# Altium schematic sheet Measuring.SchDoc(Measuring)
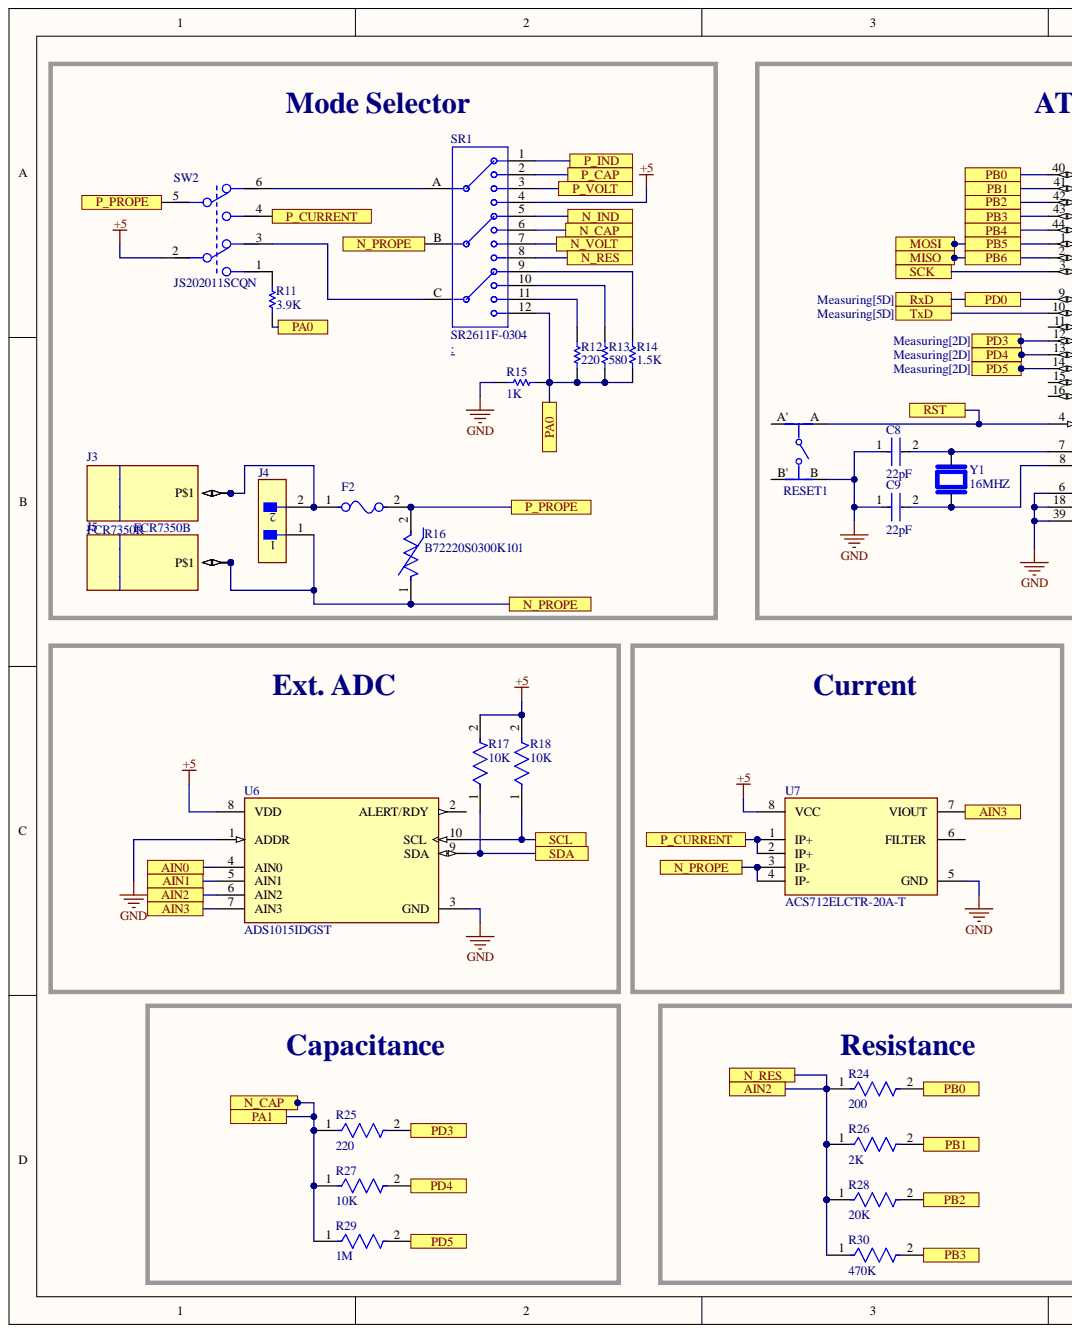
[diagram p0: Measuring.SchDoc, left]
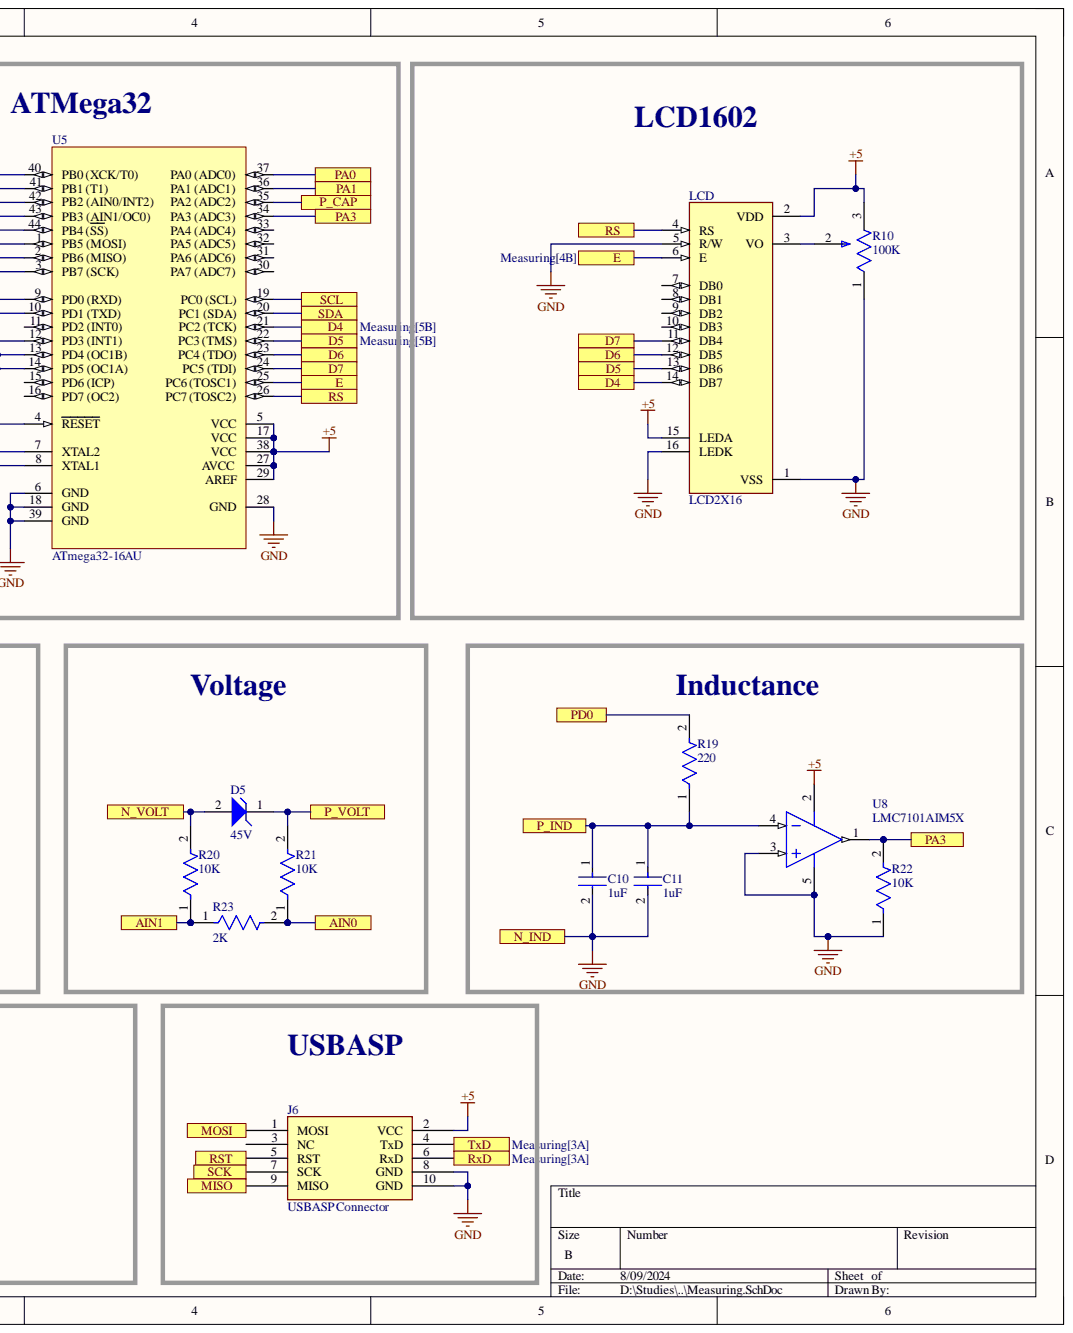
[diagram p1: Measuring.SchDoc, right]

## Parts
C8  22pF  [FP-C0603C-CF-MFG]
C9  22pF  [FP-C0603C-CF-MFG]
C10  1uF  [FP-0603-L_1_6_0_1-W_0_8_0-IPC_A]
C11  1uF  [FP-0603-L_1_6_0_1-W_0_8_0-IPC_A]
D5  45V  [ONSC-SOD-123-2-425-04_V]
F2  0154004.DR  [FP-0154-MFG]  (value not drawn)
J3  FCR7350R  [FCR7350R]
J4  B2B-XH-A(LF)(SN)  [FP-B2B-XH-A_LF_SN-MFG]  (value not drawn)
J5  FCR7350B  MP: FCR7350R  [FCR7350B]
J6  USBASP Connector  [SHDR10W99P254_2X5_2032X889X927P]
LCD  LCD2X16  [LCD_LCD2X16]
R10  100K  [FP-3269W-MFG]
R11  3.9K  [RESC1608X55X25ML10T15]
R12  220  [RESC1608X55X25ML10T15]
R13  580  [RESC1608X55X25ML10T15]
R14  1.5K  [RESC1608X55X25ML10T15]
R15  1K  [RESC1608X55X25ML10T15]
R16  B72220S0300K101  [FP-B72220S0-MFG]
R17  10K  [FP-RC0603-0_55-IPC_C]
R18  10K  [FP-RC0603-0_55-IPC_C]
R19  220  [FP-RC0603-0_55-IPC_C]
R20  10K  [FP-RC0603-0_55-IPC_C]
R21  10K  [FP-RC0603-0_55-IPC_C]
R22  10K  [FP-RC0603-0_55-IPC_C]
R23  2K  [FP-RC0603-0_55-IPC_C]
R24  200  [FP-RC0603-0_55-IPC_C]
R25  220  [FP-RC0603-0_55-IPC_C]
R26  2K  [FP-RC0603-0_55-IPC_C]
R27  10K  [FP-RC0603-0_55-IPC_C]
R28  20K  [FP-RC0603-0_55-IPC_C]
R29  1M  [FP-RC0603-0_55-IPC_C]
R30  470K  [FP-RC0603-0_55-IPC_C]
RESET1  EVQ-Q2B02W  [FP-EVQ-Q2B02W-MFG]  (value not drawn)
SR1  SR2611F-0304  [SW_A30403RNCQ]
SW2  JS202011SCQN  [FP-JS202011SCQN-MFG]
U5  ATmega32-16AU  [44A_N]
U6  ADS1015IDGST  [FP-DGS0010A-MFG]
U7  ACS712ELCTR-20A-T  [FP-LC-8-IPC_C]
U8  LMC7101AIM5X  [DBV0005A_L]
Y1  16MHZ  [XTAL_NX5032GA-16.000000MHZ-LN-CD-1]

## Ports
AIN0, AIN1, AIN2, AIN3, D4, D5, D6, D7, E, MISO, MOSI, N_CAP, N_IND, N_PROPE, N_RES, N_VOLT, P_CAP, P_CURRENT, P_IND, P_PROPE, P_VOLT, PA0, PA1, PA3, PB0, PB1, PB2, PB3, PB4, PB5, PB6, PD0, PD3, PD4, PD5, RS, RST, RxD, SCK, SCL, SDA, TxD

## Nets
+5: J6.2(VCC) LCD.2(VDD) LCD.15(LEDA) R10.3 R17.2 R18.2 SR1.4 SW2.2 U5.5(VCC) U5.17(VCC) U5.27(AVCC) U5.29(AREF) U5.38(VCC) U6.8(VDD) U7.8(VCC) U8.2
AIN0: R21.1 R23.2 U6.4(AIN0) ; port AIN0
AIN1: R20.1 R23.1 U6.5(AIN1) ; port AIN1
AIN2: R24.1 R26.1 R28.1 R30.1 SR1.8 U6.6(AIN2) ; port AIN2, port N_RES
AIN3: U6.7(AIN3) U7.7(VIOUT) ; port AIN3
D4: LCD.14(DB7) U5.21(PC2_(TCK)) ; port D4
D5: LCD.13(DB6) U5.22(PC3_(TMS)) ; port D5
D6: LCD.12(DB5) U5.23(PC4_(TDO)) ; port D6
D7: LCD.11(DB4) U5.24(PC5_(TDI)) ; port D7
E: LCD.6(E) U5.25(PC6_(TOSC1)) ; port E
GND: C8.1 C9.1 C10.2 C11.2 J6.8(GND) J6.10(GND) LCD.1(VSS) LCD.5(R/W) LCD.16(LEDK) R10.1 R15.1 R22.1 RESET1.B SR1.5 U5.6(GND) U5.18(GND) U5.28(GND) U5.39(GND) U6.1(ADDR) U6.3(GND) U7.5(GND) U8.3 U8.5 ; port N_IND
MISO: J6.9(MISO) U5.2(PB6_(MISO)) ; port MISO, port PB6
MOSI: J6.1(MOSI) U5.1(PB5_(MOSI)) ; port MOSI, port PB5
N_CAP: R25.1 R27.1 R29.1 SR1.6 U5.36(PA1_(ADC1)) ; port N_CAP, port PA1
N_PROPE: J4.1 J5.P$1 J5.P$2 J5.P$3 J5.P$4 J5.P$5 R16.1 SR1.B U7.3(IP-) U7.4(IP-) ; port N_PROPE
N_VOLT: D5.2 R20.2 SR1.7 ; port N_VOLT
P_CAP: SR1.2 U5.35(PA2_(ADC2)) ; port P_CAP
P_CURRENT: SW2.4 U7.1(IP+) U7.2(IP+) ; port P_CURRENT
P_IND: C10.1 C11.1 R19.1 SR1.1 U8.4 ; port P_IND
P_PROPE: F2.2 R16.2 SW2.5 ; port P_PROPE
P_VOLT: D5.1 R21.2 SR1.3 ; port P_VOLT
PA0: R11.2 R12.2 R13.2 R14.2 R15.2 SR1.12 U5.37(PA0_(ADC0)) ; port PA0
PA3: R22.2 U5.34(PA3_(ADC3)) U8.1 ; port PA3
PB0: R24.2 U5.40(PB0_(XCK/T0)) ; port PB0
PB1: R26.2 U5.41(PB1_(T1)) ; port PB1
PB2: R28.2 U5.42(PB2_(AIN0/INT2)) ; port PB2
PB3: R30.2 U5.43(PB3_(AIN1/OC0)) ; port PB3
PB4: U5.44(PB4_(SS)) ; port PB4
PD0: J6.6(RxD) R19.2 U5.9(PD0_(RXD)) ; port PD0, port RxD
PD3: R25.2 U5.12(PD3_(INT1)) ; port PD3
PD4: R27.2 U5.13(PD4_(OC1B)) ; port PD4
PD5: R29.2 U5.14(PD5_(OC1A)) ; port PD5
RS: LCD.4(RS) U5.26(PC7_(TOSC2)) ; port RS
RST: J6.5(RST) RESET1.A U5.4(RESET) ; port RST
SCK: J6.7(SCK) U5.3(PB7_(SCK)) ; port SCK
SCL: R18.1 U5.19(PC0_(SCL)) U6.10(SCL) ; port SCL
SDA: R17.1 U5.20(PC1_(SDA)) U6.9(SDA) ; port SDA
TxD: J6.4(TxD) U5.10(PD1_(TXD)) ; port TxD
NetC8_2: C8.2 U5.7(XTAL2) Y1.2
NetC9_2: C9.2 U5.8(XTAL1) Y1.1
NetF2_1: F2.1 J3.P$1 J3.P$2 J3.P$3 J3.P$4 J3.P$5 J4.2
NetLCD_3: LCD.3(VO) R10.2
NetR11_1: R11.1 SW2.1
NetR12_1: R12.1 SR1.11
NetR13_1: R13.1 SR1.10
NetR14_1: R14.1 SR1.9
NetSR1_A: SR1.A SW2.6
NetSR1_C: SR1.C SW2.3

Not connected: J6 3(NC); LCD 7(DB0) 8(DB1) 9(DB2) 10(DB3); RESET1 A' B'; U5 11(PD2_(INT0)) 15(PD6_(ICP)) 16(PD7_(OC2)) 30(PA7_(ADC7)) 31(PA6_(ADC6)) 32(PA5_(ADC5)) 33(PA4_(ADC4)); U6 2(ALERT/RDY); U7 6(FILTER)

## Library data of the parts
C8 C9 = CMP-2006-03119-3: Cap Ceramic 22pF 50V C0G 5% SMD 0603 125°C Paper T/R; Manufacturer: KEMET; Manufacturer Part Number: C0603C220J5GACTU; Automotive Grade: Grade 1; Temperature Coefficient: 30ppm/°C; Tolerance: 0.05; Automotive: Yes; Capacitance: 22 pF; Category: Cap; Component Kind: Standard; ComponentLink2Description: Datasheet; ComponentLink2URL: https://api.kemet.com/component-edge/download/datasheet/C0603C100J5GACAUTO.pdf; Device Class L1: Passive Components; Device Class L2: Capacitors; Device Class L3: Ceramic Capacitors; Digikey Description: Cap Ceramic 22pF 50V C0G 5% SMD 0603 125°C Paper T/R; Height: 0.87mm; Lead Free: Yes; Library Name: Altium Content Vault; Material: Ceramic; Mouser Description: Cap Ceramic 22pF 50V C0G 5% SMD 0603 125°C Paper T/R; Octopart: https://octopart.com/c0603c220j5gactu-kemet-98525; Package: C0603C-CF; RoHS: TRUE; Temperature: -55°C to +125°C; Temperature Characteristic: C0G; Temperature Range High: +125°C; Temperature Range Low: -55°C; Value: 22 pF; Voltage Rating: 50 V
C10 C11 = CMP-2000-05447-3: None; Dielectric: X5R; Tolerance: 10%; Voltage Rating: 50V; Capacitance: 1uF; Case Code (Imperial): 0603; Case Code (Metric): 1608; Case/Package: 0603; Component Kind: Standard; Construction: Flat; Contact Plating: Nickel; Depth: 0.8mm; Halogen Free: Halogen Free; Height: 0.8mm; Length: 1.6mm; Library Name: Altium Content Vault; Max Operating Temperature: 85°C; Min Operating Temperature: -55°C; Mount: Surface Mount; Number of Pins: 2; Package Quantity: 1; Packaging: Tape and Reel; Radiation Hardening: No; RoHS Compliant: Yes; Series: M; Termination: SMD/SMT; Thickness: 0.035inch; Voltage Rating (DC): 50V; Width: 0.031inch
D5 = CMP-1060-00318-1: Zener Voltage Regulator, 500 mW, 2-Pin SOD-123, Pb-Free, Tape and Reel; Manufacturer: On Semiconductor; PartNumber: MMSZ4713T1G; P Max (W): 0.5; VZ Typ (V): 30; Component Kind: Standard; ComponentLink1Description: Manufacturer URL; ComponentLink1URL: http://www.onsemi.com/; ComponentLink2Description: Datasheet; ComponentLink2URL: http://www.onsemi.com/pub_link/Collateral/MMSZ4678T1-D.PDF; ComponentLink3Description: Package Specification; ComponentLink3URL: http://www.onsemi.com/pub_link/Collateral/425-04.PDF; DatasheetVersion: Rev. 9; Library Name: Altium Content Vault; Mounting Technology: Surface Mount; PackageDescription: 2-Pin SOD, Body 1.6 x 2.69 mm; PackageReference: SOD-123-2-425-04; PackageVersion: Rev. G, 10/2009; Packing: Tape and Reel; RoHS: Pb-Free
F2 = CMP-08605-000003-1: FUSE BRD MNT 4A 125VAC/VDC 2SMD; Manufacturer: Littelfuse; Fuse Blow Speed: Fast; Max Fuse Ac Voltage: 125V; Automotive: No; Category: Fuse; Component Kind: Standard; ComponentLink2Description: Datasheet; ComponentLink2URL: https://www.littelfuse.com/~/media/electronics/datasheets/fuses/littelfuse_fuse_154_154t_154l_154tl_datasheet.pdf.pdf; Device Class L1: Circuit Protection; Device Class L2: Fuses; Device Class L3: unset; Digikey Description: FUSE BRD MNT 4A 125VAC/VDC 2SMD; Fuse Current: 4A; Height: 3.81mm; Lead Free: Yes; Library Name: Altium Content Vault; Manufacturer Part Number: 0154004.DR; Max Fuse Dc Voltage: 125V; Mouser Description: Surface Mount Fuses Fuseblock w/ fuse 4A OMNI BLOK 154; Octopart: https://octopart.com/0154004.dr-littelfuse-565861; Package: 0154; RoHS: TRUE; Temperature: -55°C to +125°C; Temperature Range High: +125°C; Temperature Range Low: -55°C
J3 = FCR7350R: Banana Jack Connector Standard Banana Solder Red; MF: Cliff; Check_prices: https://www.snapeda.com/parts/FCR7350R/Cliff+Electronics/view-part/?ref=eda; Component Kind: Standard; MP: FCR7350R; Package: None; SnapEDA_Link: https://www.snapeda.com/parts/FCR7350R/Cliff+Electronics/view-part/?ref=snap; Library Name: YoussifLIB.SchLib
J4 = CMP-2000-05888-3: CONN HEADER VERT 2POS 2.5MM; Connector Type: Male Pin; Contact Resistance: 20mR; Current Rating: 3A; Insulation Resistance: 1GR; Max Voltage Rating (AC): 250V; Number of Contacts: 2; Wire/Cable Gauge: 22AWG; Color: Natural; Component Kind: Standard; Contact Material: Brass; Contact Plating: Tin; Contact Type: Male Pin; Depth: 5.75mm; Fastening Type: Detent; Housing Color: White; Housing Material: Polyamide; Lead Free: Lead Free; Lead Pitch: 2.5mm; Length: 7.4mm; Library Name: Altium Content Vault; Max Current Rating: 3A; Max Operating Temperature: 85°C; Max Voltage Rating (DC): 250V; Min Operating Temperature: -25°C; Mount: Through Hole; Number of Positions: 2; Number of Rows: 1; Orientation: Straight; Packaging: Bulk; Pitch: 2.5mm; REACH SVHC: No SVHC; Series: XH; Termination: Solder; Voltage Rating: 250V; Voltage Rating (AC): 250V
J5 = FCR7350B: Banana Jack Connector Standard Banana Solder Black; MF: Cliff; Check_prices: https://www.snapeda.com/parts/FCR7350R/Cliff+Electronics/view-part/?ref=eda; Component Kind: Standard; Package: None; SnapEDA_Link: https://www.snapeda.com/parts/FCR7350R/Cliff+Electronics/view-part/?ref=snap; Library Name: YoussifLIB.SchLib
J6 = USBASP Connector: Connector; Manufacturer_Name: Molex; Manufacturer_Part_Number: 70246-1001; Component Kind: Standard; Datasheet Link: http://www.molex.com/pdm_docs/sd/702461001_sd.pdf; Height: 9.27mm; Mouser Part Number: 538-70246-1001; Mouser Price/Stock: https://www.mouser.co.uk/ProductDetail/Molex/70246-1001?qs=L7RdmAxh%252BcP9d7Ope9dnAA%3D%3D; Library Name: YoussifLIB.SchLib
LCD = LCD2X16: Display; Display: LCD 2x16 characters (blue backlight); Availability: Unavailable; Component Kind: Standard; MP: LCD2X16; Package: None; Price: None; Library Name: YoussifLIB.SchLib
R10 = CMP-07234-000021-1: TRIMMER 100K OHM 0.25W SMD; Manufacturer: Bourns; Manufacturer Part Number: 3269W-1-104LF; Resistance: 100kΩ; Temperature Coefficient: 100ppm/°C; Tolerance: 10%; Value: 100kΩ; Automotive: No; Category: Res; Component Kind: Standard; ComponentLink2Description: Datasheet; ComponentLink2URL: https://www.bourns.com/docs/Product-Datasheets/3269.pdf; Composition: Cermet; Device Class L1: Passive Components; Device Class L2: Resistors; Device Class L3: Variable Resistors and Potentiometers; Digikey Description: TRIMMER 100K OHM 0.25W SMD; Height: 8.96mm; Lead Free: Yes; Library Name: Altium Content Vault; Mouser Description: Res Cermet Trimmer 100K Ohm 10% 1/4W 12(Elec)Turn 1.78mm (6.35 X 6.36 X 8.46mm) Gull Wing SMD Tube; Octopart: https://octopart.com/3269w-1-104lf-bourns-114162; Package: 3269W; Power Rating: 0.25W; RoHS: TRUE; Series: 3269; Temperature: -65°C to +150°C; Temperature Range High: +150°C; Temperature Range Low: -65°C
R11-R14 = CMP-2100-03667-1: Chip Resistor, 0 Ohm, +/- 5%, 0.1 W, -55 to 155 degC, 0603 (1608 Metric), RoHS, Tape and Reel; Manufacturer: Yageo; Power Rating: 100mW; Resistance: 1K; Temperature Coefficient: 200ppm/degreesC; Voltage Rating: 50V; Case Code (Imperial): 0603; Case Code (Metric): 1608; Case/Package: 0603; Component Kind: Standard; Composition: ThickFilm; Contact Plating: Tin; Datasheet URL: http://www.yageo.com/NewPortal/yageodocoutput?fileName=/pdf/R-Chip/PYu-RC_Group_51_RoHS_L_5.pdf; Datasheet Version: Rev. 5, 10/2016; Depth: 0.8mm; Height: 0.45mm; Lead Free: LeadFree; Length: 1.6mm; Library Name: Altium Content Vault; Manufacturer URL: http://www.yageo.com/; Max Operating Temperature: 155degC; Military Standard: Not; Min Operating Temperature: -55degC; Number of Pins: 2; Package Description: 2-Pin Surface Mount Device, Body 1.6 x 0.8 mm; Package Quantity: 5000; Package Reference: 0603; Packaging: TapeandReel; Radiation Hardening: No; REACH SVHC: NoSVHC; RoHSCompliant: true; Termination: SMD/SMT; Tolerance: 5%; Voltage Rating (DC): 50V; Width: 0.8mm
R15 = CMP-2100-03667-1: Chip Resistor, 0 Ohm, +/- 5%, 0.1 W, -55 to 155 degC, 0603 (1608 Metric), RoHS, Tape and Reel; Manufacturer: Yageo; Power Rating: 100mW; Temperature Coefficient: 200ppm/degreesC; Voltage Rating: 50V; Case Code (Imperial): 0603; Case Code (Metric): 1608; Case/Package: 0603; Component Kind: Standard; Composition: ThickFilm; Contact Plating: Tin; Datasheet URL: http://www.yageo.com/NewPortal/yageodocoutput?fileName=/pdf/R-Chip/PYu-RC_Group_51_RoHS_L_5.pdf; Datasheet Version: Rev. 5, 10/2016; Depth: 0.8mm; Height: 0.45mm; Lead Free: LeadFree; Length: 1.6mm; Library Name: Altium Content Vault; Manufacturer URL: http://www.yageo.com/; Max Operating Temperature: 155degC; Military Standard: Not; Min Operating Temperature: -55degC; Number of Pins: 2; Package Description: 2-Pin Surface Mount Device, Body 1.6 x 0.8 mm; Package Quantity: 5000; Package Reference: 0603; Packaging: TapeandReel; Radiation Hardening: No; REACH SVHC: NoSVHC; Resistance: 1K; RoHSCompliant: true; Termination: SMD/SMT; Tolerance: 5%; Voltage Rating (DC): 50V; Width: 0.8mm
R16 = CMP-10618-000002-1: VARISTOR 45VAC 2KA DISC 20MM; Manufacturer: EPCOS; Capacitance: 9350pF; Clamping Current: 20A; Clamping Voltage: 93V; Value: 9350pF; Varistor Voltage: 47V; Voltage Rating Ac: 30V; Voltage Rating Dc: 38V; Voltage Tolerance: 10%; Automotive: No; Category: Res; Component Kind: Standard; ComponentLink2Description: Datasheet; ComponentLink2URL: https://www.tdk-electronics.tdk.com/inf/70/db/var/SIOV_Leaded_StandarD.pdf; Device Class L1: Circuit Protection; Device Class L2: Varistors; Device Class L3: unset; Digikey Description: VARISTOR 47V 2KA DISC 20MM; Height: 25.5mm; Lead Free: Yes; Library Name: Altium Content Vault; Manufacturer Part Number: B72220S0300K101; Mouser Description: Epcos Standard Series Metal Oxide Varistor 9.35nF 20A; Clamping 93V; Varistor 47V; Octopart: https://octopart.com/b72220s0300k101-epcos-10532994; Package: B72220S0; RoHS: TRUE; Temperature: -40°C to +105°C; Temperature Range High: +105°C; Temperature Range Low: -40°C
R17 R18 R20 R21 R23-R30 = CMP-2100-03664-2: RES 10K OHM 1% 1/10W 0603; Max Power Dissipation: 100mW; Resistance: 10kR; Temperature Coefficient: 100ppm/°C; Voltage Rating: 75V; Voltage Rating (DC): 50V; Case Code (Imperial): 0603; Case Code (Metric): 1608; Case/Package: 0603; Component Kind: Standard; Construction: Rectangular; Depth: 0.8mm; Features: Moisture Resistant; Height: 0.45mm; Lead Free: Lead Free; Lead Pitch: 0.3mm; Length: 1.6mm; Library Name: Altium Content Vault; Max Operating Temperature: 155°C; Military Standard: Not; Min Operating Temperature: -55°C; Number of Pins: 2; Number of Terminations: 2; Packaging: Tape and Reel; Power Rating: 100mW; Resistance Tolerance: 1%; Resistor Type: Thick Film; RoHS Compliant: Yes; Series: RC; Size Code: 0603; Termination: SMD/SMT; Tolerance: 1%; Weight: 7.1E-05oz; Width: 0.8mm
R19 = CMP-2100-03664-2: RES 10K OHM 1% 1/10W 0603; Max Power Dissipation: 100mW; Resistance: 220R; Temperature Coefficient: 100ppm/°C; Voltage Rating: 75V; Voltage Rating (DC): 50V; Case Code (Imperial): 0603; Case Code (Metric): 1608; Case/Package: 0603; Component Kind: Standard; Construction: Rectangular; Depth: 0.8mm; Features: Moisture Resistant; Height: 0.45mm; Lead Free: Lead Free; Lead Pitch: 0.3mm; Length: 1.6mm; Library Name: Altium Content Vault; Max Operating Temperature: 155°C; Military Standard: Not; Min Operating Temperature: -55°C; Number of Pins: 2; Number of Terminations: 2; Packaging: Tape and Reel; Power Rating: 100mW; Resistance Tolerance: 1%; Resistor Type: Thick Film; RoHS Compliant: Yes; Series: RC; Size Code: 0603; Termination: SMD/SMT; Tolerance: 1%; Weight: 7.1E-05oz; Width: 0.8mm
R22 = CMP-2100-03664-2: RES 10K OHM 1% 1/10W 0603; Max Power Dissipation: 100mW; Resistance: 10KR; Temperature Coefficient: 100ppm/°C; Voltage Rating: 75V; Voltage Rating (DC): 50V; Case Code (Imperial): 0603; Case Code (Metric): 1608; Case/Package: 0603; Component Kind: Standard; Construction: Rectangular; Depth: 0.8mm; Features: Moisture Resistant; Height: 0.45mm; Lead Free: Lead Free; Lead Pitch: 0.3mm; Length: 1.6mm; Library Name: Altium Content Vault; Max Operating Temperature: 155°C; Military Standard: Not; Min Operating Temperature: -55°C; Number of Pins: 2; Number of Terminations: 2; Packaging: Tape and Reel; Power Rating: 100mW; Resistance Tolerance: 1%; Resistor Type: Thick Film; RoHS Compliant: Yes; Series: RC; Size Code: 0603; Termination: SMD/SMT; Tolerance: 1%; Weight: 7.1E-05oz; Width: 0.8mm
RESET1 = CMP-05618-000078-1: SWITCH TACTILE SPST-NO 0.02A 15V; Actuator Color: White; Actuator Length: 0.7mm; Actuator Type: Standard; Contact Current Rating: 20mA; Contact Resistance: 100mR; Electrical Life: 2000000Cycles; Illumination Type: Non-Illuminated; Operating Force: 0.5N; Switch Function: Off-Mom; Switch Travel: 0.2mm; Throw Configuration: SPST; Voltage Rating (AC): 250V; Case/Package: SMD/SMT; Color: White; Component Kind: Standard; Contact Plating: Silver; Current Rating: 20mA; Lead Free: Lead Free; Library Name: Altium Content Vault; Max Operating Temperature: 85°C; Max Voltage Rating (DC): 15V; Mechanical Life: 2000000Cycles; Min Operating Temperature: -40°C; Mount: Surface Mount; Orientation: Straight; Package Quantity: 1; Packaging: Tape and Reel; Radiation Hardening: No; RoHS Compliant: Yes; Series: EVQQ2; Size: 1.8mm; Termination: SMD/SMT; Thickness: 1.8mm; Voltage Rating (DC): 15V
SR1 = SR2611F-0304: Rotary Switch 4 Position 3P4T 2.5A (AC), 350mA (DC) 125VAC Panel Mount, Through Hole; MF: C&K; MP: A30403RNCQ; Availability: Unavailable; Component Kind: Standard; Package: None; Price: None; SnapEDA_Link: https://www.snapeda.com/parts/A30403RNCQ/C&K/view-part/438327/?ref=snap; Library Name: YoussifLIB.SchLib
SW2 = CMP-2000-05087-3: SWITCH SLIDE DPDT 300MA 6V; Actuator Color: Black; Actuator Length: 2mm; Actuator Material: Nylon; Actuator Type: Standard; Contact Resistance: 70mR; Electrical Life: 5000Cycles; Switch Function: On-On; Component Kind: Standard; Contact Current Rating: 300mA; Contact Material: Copper Alloy; Contact Plating: Silver; Current Rating: 300mA; Depth: 7.5mm; Flammability Rating: UL94 V-2; Housing Material: Nylon; Lead Free: Lead Free; Length: 9mm; Library Name: Altium Content Vault; Max Current Rating: 300mA; Max Operating Temperature: 85°C; Max Voltage Rating (DC): 6V; Min Operating Temperature: -20°C; Mount: Surface Mount; Orientation: Vertical; Package Quantity: 1; Packaging: Tape and Reel; Radiation Hardening: No; REACH SVHC: No SVHC; Series: JS; Switch Travel: 2mm; Termination: Gull Wing; Throw Configuration: DPDT; Voltage Rating (DC): 6V
U5 = CMP-0095-00554-1: 8-bit AVR Microcontroller, 4.5-5.5V, 16MHz, 32KB Flash, 1024 Bytes EEPROM, 2KB SRAM, 44-pin TQFP, Industrial Grade (-40°C to 85°C); Code_JEDEC: MS-026-ACB; Component Kind: Standard; ComponentLink1Description: Manufacturer URL; ComponentLink1URL: http://www.atmel.com/; ComponentLink2Description: ATmega32(L) Datasheet; ComponentLink2URL: http://atmel.com/dyn/resources/prod_documents/doc2503.pdf; ComponentLink3Description: ATmega32(L) Summary; ComponentLink3URL: http://atmel.com/dyn/resources/prod_documents/2503S.pdf; DatasheetVersion: revQ, Feb-2011; Library Name: Altium Content Vault; PackageDescription: 44-Pin Plastic Thin Profile Quad Flat Pack, 10 x 10 x 1.0 mm Body, 0.8 mm Pitch (TQFP); PackageReference: 44A; PackageVersion: revC, Oct-2010
U6 = CMP-0003-00377-3: IC ADC 12BIT SIGMA-DELTA 10VSSOP; Conversion Rate: 3300S/s; Integral Nonlinearity (INL): 0.5LSB; Interface: I2C; Max Power Dissipation: 900uW; Max Supply Voltage: 5.5V; Min Supply Voltage: 2V; Nominal Supply Current: 150uA; Number of A/D Converters: 1; Number of Bits: 12; Number of Channels: 4; Operating Supply Voltage: 3.3V; Polarity: Bipolar; Resolution: 12b; Sampling Rate: 3.3KS/s; Supply Type: Single; Case/Package: VSSOP; Component Kind: Standard; Contact Plating: Gold; Height: 1.02mm; Lead Free: Lead Free; Length: 3mm; Library Name: Altium Content Vault; Max Operating Temperature: 125°C; Max Supply Voltage (DC): 5.5V; Min Operating Temperature: -40°C; Min Supply Voltage (DC): 2V; Number of Converters: 1; Number of Elements: 1; Number of Inputs: 4; Number of Pins: 10; Package Quantity: 250; Packaging: Tape and Reel; Power Dissipation: 900uW; Radiation Hardening: No; REACH SVHC: No SVHC; Termination: SMD/SMT; Width: 3mm
U7 = CMP-0826-00001-2: SENSOR CURRENT HALL 20A AC/DC; Accuracy: 1.5%; Bandwidth: 80kHz; Max Supply Current: 13mA; Max Supply Voltage: 5.5V; Min Supply Voltage: 4.5V; Number of Channels: 1; Operating Supply Voltage: 5V; Output Current: 10mA; Polarity: Bidirectional; Response Time: 5us; Sensitivity: 100mV/A; Case/Package: SOIC; Component Kind: Standard; Current Sensing: 20A; Frequency: 80kHz; Height: 1.5mm; Lead Free: Lead Free; Length: 4.9mm; Library Name: Altium Content Vault; Linearity: 1.5%; Max Operating Temperature: 85°C; Min Operating Temperature: -40°C; Number of Pins: 8; Package Quantity: 1; Packaging: Tape and Reel; Quiescent Current: 10mA; Radiation Hardening: No; REACH SVHC: No SVHC; RoHS Compliant: Yes; Series: -; Termination: SMD/SMT; Width: 3.9mm
U8 = CMP-0055-01331-3: Tiny Low Power Operational Amplifier with Rail-to-Rail Input and Output, 5-pin SOT-23; Manufacturer: Texas Instruments; CompType: Amplifiers; PackageTopMarking: A00A*XTT; PowerWise: N; Component Kind: Standard; ComponentLink1Description: Datasheet URL; ComponentLink1URL: http://www.ti.com/general/docs/lit/getliterature.tsp?genericPartNumber=LMC7101&fileType=pdf; Library Name: Altium Content Vault; Package Reference: DBV0005A; PackageDescription: Molded SOT-23, .115 x .063 x .040 in Body, 5 Lead, .0375 in Pitch; PinCount: 5; RoHS: N
Y1 = NX5032GA-16.000000MHZ-LN-CD-1: Availability: Unavailable; Component Kind: Standard; Library Name: NX5032GA-16.000000MHZ-LN-CD-1.IntLib; MP: NX5032GA-16.000000MHZ-LN-CD-1; Package: None; Price: None
